# Altium schematic sheet Main.SchDoc
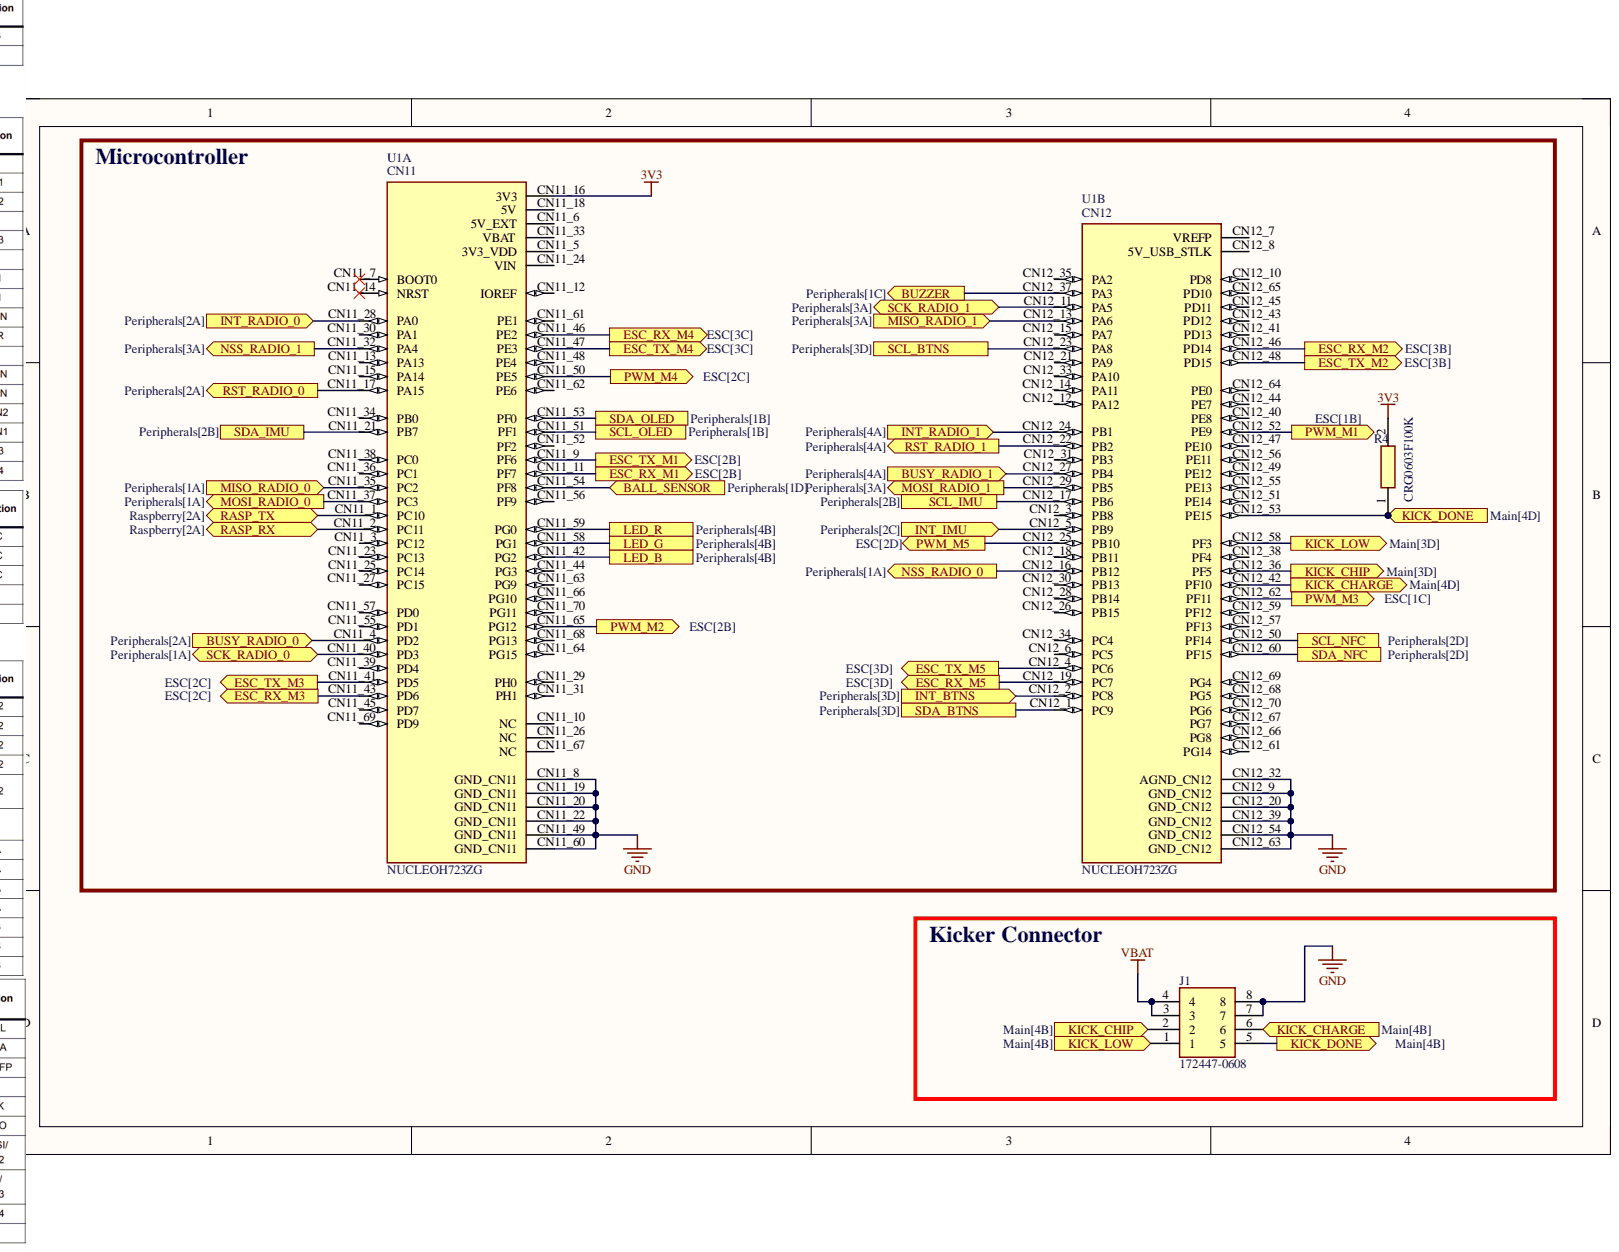
[diagram p0: Main.SchDoc]

## Parts
J1  172447-0608  [172447-YY08]
R4  CRG0603F100K  [RESC1608X55N]
U1  NUCLEOH723ZG  [MODULE_NUCLEOH723ZG]  (parts A,B)

## Ports
BALL_SENSOR, BUSY_RADIO_0, BUSY_RADIO_1, BUZZER, ESC_RX_M1, ESC_RX_M2, ESC_RX_M3, ESC_RX_M4, ESC_RX_M5, ESC_TX_M1, ESC_TX_M2, ESC_TX_M3, ESC_TX_M4, ESC_TX_M5, INT_BTNS, INT_IMU, INT_RADIO_0, INT_RADIO_1, KICK_CHARGE, KICK_CHIP, KICK_DONE, KICK_LOW, LED_B, LED_G, LED_R, MISO_RADIO_0, MISO_RADIO_1, MOSI_RADIO_0, MOSI_RADIO_1, NSS_RADIO_0, NSS_RADIO_1, PWM_M1, PWM_M2, PWM_M3, PWM_M4, PWM_M5, RASP_RX, RASP_TX, RST_RADIO_0, RST_RADIO_1, SCK_RADIO_0, SCK_RADIO_1, SCL_BTNS, SCL_IMU, SCL_NFC, SCL_OLED, SDA_BTNS, SDA_IMU, SDA_NFC, SDA_OLED

## Nets
3V3: R4.2 U1.CN11_16(3V3)
GND: J1.7 J1.8 U1.CN11_8(GND_CN11) U1.CN11_19(GND_CN11) U1.CN11_20(GND_CN11) U1.CN11_22(GND_CN11) U1.CN11_49(GND_CN11) U1.CN11_60(GND_CN11) U1.CN12_9(GND_CN12) U1.CN12_20(GND_CN12) U1.CN12_32(AGND_CN12) U1.CN12_39(GND_CN12) U1.CN12_54(GND_CN12) U1.CN12_63(GND_CN12)
VBAT: J1.3 J1.4
BALL_SENSOR: U1.CN11_54(PF8) ; port BALL_SENSOR
BUSY_RADIO_0: U1.CN11_4(PD2) ; port BUSY_RADIO_0
BUSY_RADIO_1: U1.CN12_27(PB4) ; port BUSY_RADIO_1
BUZZER: U1.CN12_37(PA3) ; port BUZZER
ESC_RX_M1: U1.CN11_11(PF7) ; port ESC_RX_M1
ESC_RX_M2: U1.CN12_46(PD14) ; port ESC_RX_M2
ESC_RX_M3: U1.CN11_43(PD6) ; port ESC_RX_M3
ESC_RX_M4: U1.CN11_46(PE2) ; port ESC_RX_M4
ESC_RX_M5: U1.CN12_19(PC7) ; port ESC_RX_M5
ESC_TX_M1: U1.CN11_9(PF6) ; port ESC_TX_M1
ESC_TX_M2: U1.CN12_48(PD15) ; port ESC_TX_M2
ESC_TX_M3: U1.CN11_41(PD5) ; port ESC_TX_M3
ESC_TX_M4: U1.CN11_47(PE3) ; port ESC_TX_M4
ESC_TX_M5: U1.CN12_4(PC6) ; port ESC_TX_M5
INT_BTNS: U1.CN12_2(PC8) ; port INT_BTNS
INT_IMU: U1.CN12_5(PB9) ; port INT_IMU
INT_RADIO_0: U1.CN11_28(PA0) ; port INT_RADIO_0
INT_RADIO_1: U1.CN12_24(PB1) ; port INT_RADIO_1
KICK_CHARGE: J1.6 U1.CN12_42(PF10) ; port KICK_CHARGE
KICK_CHIP: J1.2 U1.CN12_36(PF5) ; port KICK_CHIP
KICK_DONE: J1.5 R4.1 U1.CN12_53(PE15) ; port KICK_DONE
KICK_LOW: J1.1 U1.CN12_58(PF3) ; port KICK_LOW
LED_B: U1.CN11_42(PG2) ; port LED_B
LED_G: U1.CN11_58(PG1) ; port LED_G
LED_R: U1.CN11_59(PG0) ; port LED_R
MISO_RADIO_0: U1.CN11_35(PC2) ; port MISO_RADIO_0
MISO_RADIO_1: U1.CN12_13(PA6) ; port MISO_RADIO_1
MOSI_RADIO_0: U1.CN11_37(PC3) ; port MOSI_RADIO_0
MOSI_RADIO_1: U1.CN12_29(PB5) ; port MOSI_RADIO_1
NSS_RADIO_0: U1.CN12_16(PB12) ; port NSS_RADIO_0
NSS_RADIO_1: U1.CN11_32(PA4) ; port NSS_RADIO_1
PWM_M1: U1.CN12_52(PE9) ; port PWM_M1
PWM_M2: U1.CN11_65(PG12) ; port PWM_M2
PWM_M3: U1.CN12_62(PF11) ; port PWM_M3
PWM_M4: U1.CN11_50(PE5) ; port PWM_M4
PWM_M5: U1.CN12_25(PB10) ; port PWM_M5
RASP_RX: U1.CN11_2(PC11) ; port RASP_RX
RASP_TX: U1.CN11_1(PC10) ; port RASP_TX
RST_RADIO_0: U1.CN11_17(PA15) ; port RST_RADIO_0
RST_RADIO_1: U1.CN12_22(PB2) ; port RST_RADIO_1
SCK_RADIO_0: U1.CN11_40(PD3) ; port SCK_RADIO_0
SCK_RADIO_1: U1.CN12_11(PA5) ; port SCK_RADIO_1
SCL_BTNS: U1.CN12_23(PA8) ; port SCL_BTNS
SCL_IMU: U1.CN12_17(PB6) ; port SCL_IMU
SCL_NFC: U1.CN12_50(PF14) ; port SCL_NFC
SCL_OLED: U1.CN11_51(PF1) ; port SCL_OLED
SDA_BTNS: U1.CN12_1(PC9) ; port SDA_BTNS
SDA_IMU: U1.CN11_21(PB7) ; port SDA_IMU
SDA_NFC: U1.CN12_60(PF15) ; port SDA_NFC
SDA_OLED: U1.CN11_53(PF0) ; port SDA_OLED

Not connected: U1 CN11_3(PC12) CN11_5(3V3_VDD) CN11_6(5V_EXT) CN11_7(BOOT0) CN11_10(NC) CN11_12(IOREF) CN11_13(PA13) CN11_14(NRST) CN11_15(PA14) CN11_18(5V) CN11_23(PC13) CN11_24(VIN) CN11_25(PC14) CN11_26(NC) CN11_27(PC15) CN11_29(PH0) CN11_30(PA1) CN11_31(PH1) CN11_33(VBAT) CN11_34(PB0) CN11_36(PC1) CN11_38(PC0) CN11_39(PD4) CN11_44(PG3) CN11_45(PD7) CN11_48(PE4) CN11_52(PF2) CN11_55(PD1) CN11_56(PF9) CN11_57(PD0) CN11_61(PE1) CN11_62(PE6) CN11_63(PG9) CN11_64(PG15) CN11_66(PG10) CN11_67(NC) CN11_68(PG13) CN11_69(PD9) CN11_70(PG11) CN12_3(PB8) CN12_6(PC5) CN12_7(VREFP) CN12_8(5V_USB_STLK) CN12_10(PD8) CN12_12(PA12) CN12_14(PA11) CN12_15(PA7) CN12_18(PB11) CN12_21(PA9) CN12_26(PB15) CN12_28(PB14) CN12_30(PB13) CN12_31(PB3) CN12_33(PA10) CN12_34(PC4) CN12_35(PA2) CN12_38(PF4) CN12_40(PE8) CN12_41(PD13) CN12_43(PD12) CN12_44(PE7) CN12_45(PD11) CN12_47(PE10) CN12_49(PE12) CN12_51(PE14) CN12_55(PE13) CN12_56(PE11) CN12_57(PF13) CN12_59(PF12) CN12_61(PG14) CN12_64(PE0) CN12_65(PD10) CN12_66(PG8) CN12_67(PG7) CN12_68(PG5) CN12_69(PG4) CN12_70(PG6)

## Library data of the parts
J1 = 172447-0608: Connector Mate 46992-0840; Manufacturer_Name: Molex; Component Kind: Standard; Datasheet Link: https://www.molex.com/pdm_docs/sd/1724470406_sd.pdf; Height: 12.8mm; Manufacturer_Part_Number: 172447-0608; Mouser Part Number: 538-172447-0608; Mouser Price/Stock: https://www.mouser.co.uk/ProductDetail/Molex/172447-0608?qs=t7xnP681wgUaroQMnMhOkA%3D%3D; Library Name: roboime.SchLib
R4 = CRG0603F100K: Resistor; Manufacturer_Name: TE Connectivity; Arrow Part Number: CRG0603F100K; Arrow Price/Stock: https://www.arrow.com/en/products/crg0603f100k/te-connectivity?region=nac; Component Kind: Standard; Datasheet Link: http://docs-europe.electrocomponents.com/webdocs/13d1/0900766b813d116f.pdf; Height: 0.55mm; Manufacturer_Part_Number: CRG0603F100K; Mouser Part Number: 279-CRG0603F100K; Mouser Price/Stock: https://www.mouser.co.uk/ProductDetail/TE-Connectivity-Holsworthy/CRG0603F100K?qs=VHcS2MTj4gaG9fI5w0o7FA%3D%3D; Library Name: roboime.SchLib
U1 = NUCLEOH723ZG: STM32H723ZG Nucleo-144 STM32H7 STM32 MCU 32-Bit Embedded Evaluation Board; MF: STMicroelectronics; Availability: In Stock; Check_prices: https://www.snapeda.com/parts/NUCLEOH723ZG/STMicroelectronics/view-part/?ref=eda; Component Kind: Standard; MP: NUCLEOH723ZG; Package: None; Price: None; Purchase-URL: https://pricing.snapeda.com/search/part/NUCLEOH723ZG/?ref=eda; SnapEDA_Link: https://www.snapeda.com/parts/NUCLEOH723ZG/STMicroelectronics/view-part/?ref=snap; Library Name: roboime.SchLib
